# Altium schematic sheet SensorBME680.SchDoc
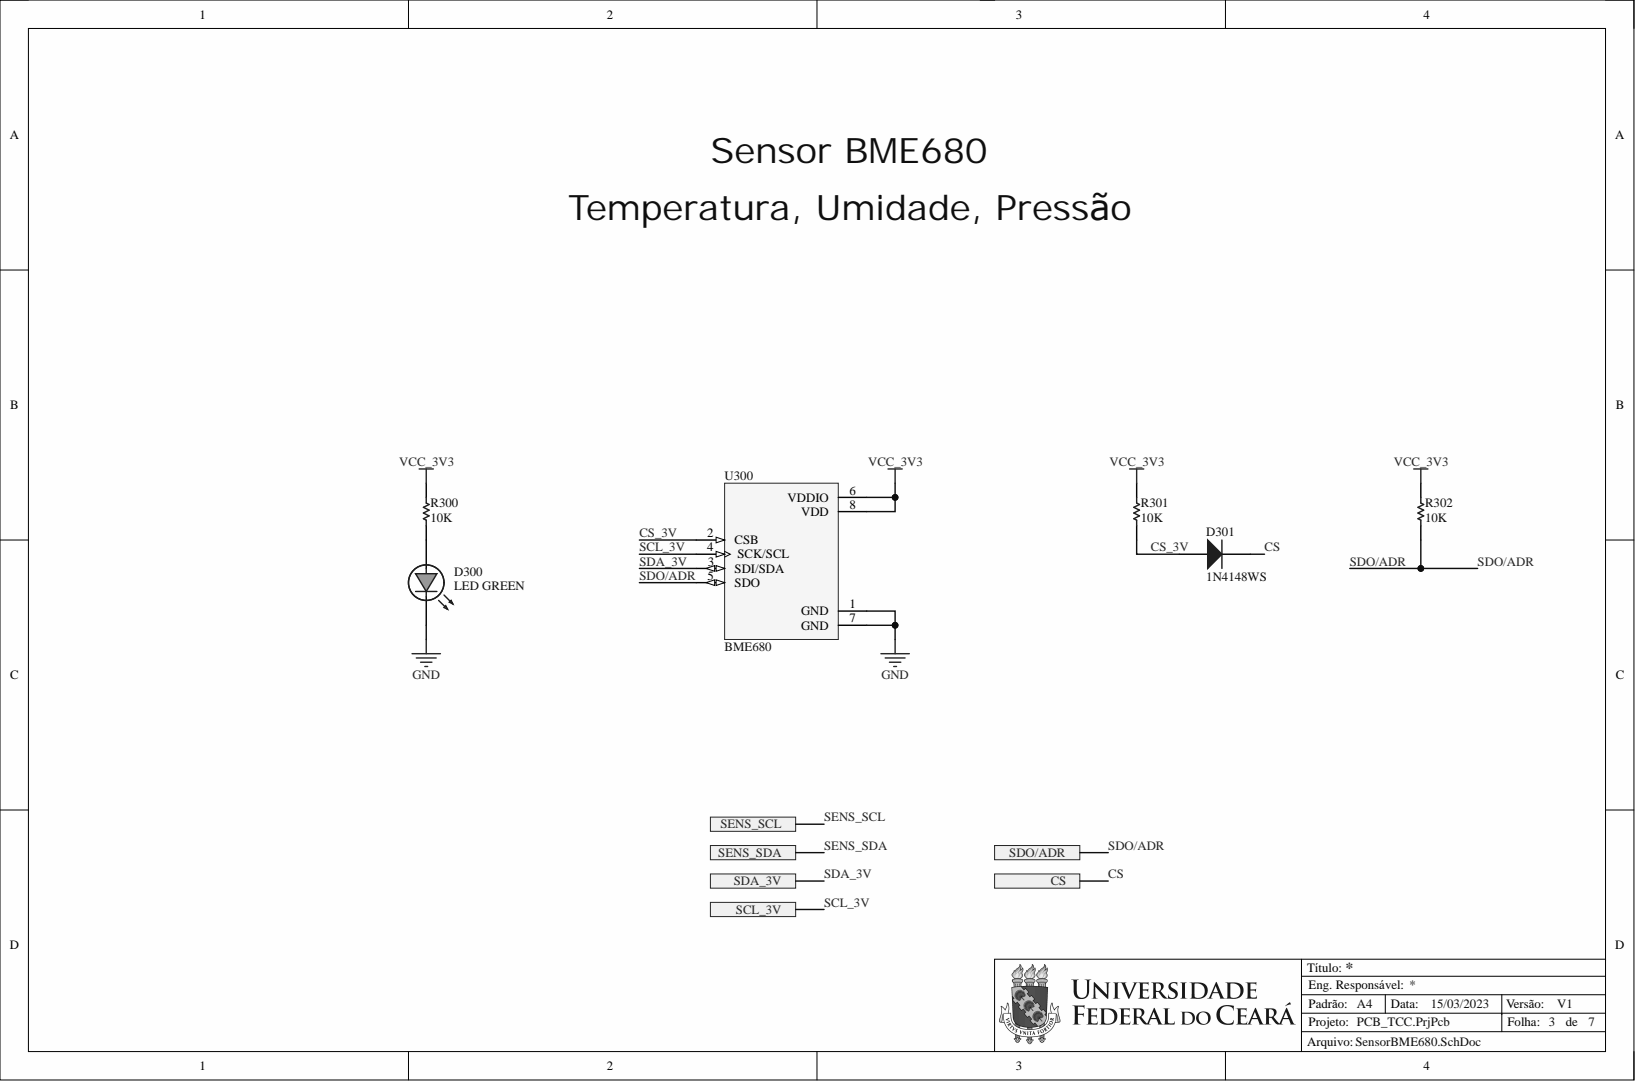
[diagram p0: SensorBME680.SchDoc]

## Parts
D300  LED GREEN  [LED_GREEN_SMD_0603]
D301  1N4148WS  [1N4148WS]
R300  10K  [RES_0603]
R301  10K  [RES_0603]
R302  10K  [RES_0603]
U300  BME680  [BME680]

## Ports
CS, SCL_3V, SDA_3V, SDO/ADR, SENS_SCL, SENS_SDA

## Nets
GND: D300.K U300.1(GND) U300.7(GND)
VCC_3V3: R300.2 R301.2 R302.2 U300.6(VDDIO) U300.8(VDD)
CS: D301.K ; port CS
CS_3V: D301.A R301.1 U300.2(CSB)
SCL_3V: U300.4(SCK/SCL) ; port SCL_3V
SDA_3V: U300.3(SDI/SDA) ; port SDA_3V
SDO/ADR: R302.1 U300.5(SDO) ; port SDO/ADR
NetD300_A: D300.A R300.1
SENS_SCL: ; port SENS_SCL
SENS_SDA: ; port SENS_SDA

## Library data of the parts
D300 = LED_GREEN_SMD_0603: Component Kind: Standard; Supplier 1: Digi-Key; Supplier Part Number 1: 754-1121-1-ND; Library Name: PCB_TCC.SchLib
R300-R302 = RES_10K_0603: Component Kind: Standard; Supplier 1: Digi-Key Marketplace; Supplier Part Number 1: 2571-RM06J103CTTR-ND; Library Name: PCB_TCC.SchLib
D301 = 1N4148WS: Component Kind: Standard; Supplier 1: Future Electronics; Supplier Part Number 1: 3369816; Library Name: PCB_TCC.SchLib
U300 = BME680: Component Kind: Standard; Supplier 1: Digi-Key; Supplier Part Number 1: 828-1077-1-ND; Library Name: PCB_TCC.SchLib
